# Altium schematic sheet SensorBoard.SchDoc
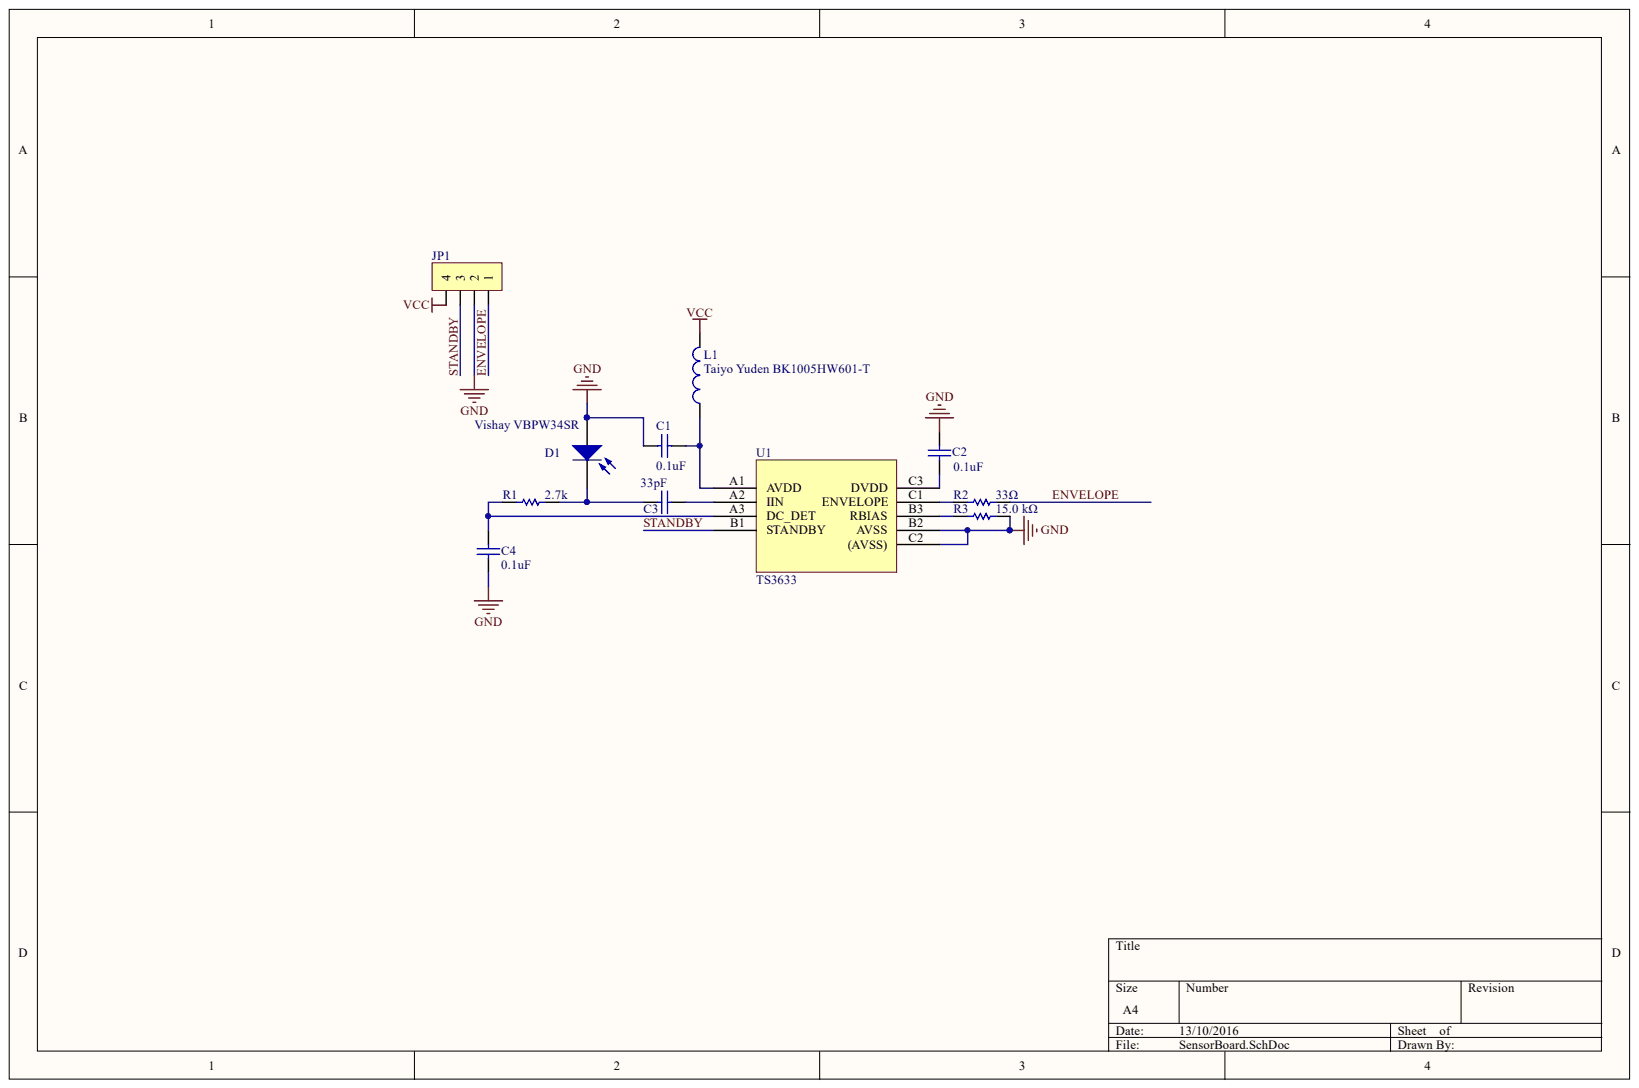
[diagram p0: SensorBoard.SchDoc]

## Parts
C1  Capacitance: 0.1uF  [CAPC1005X55X25NL05T10]  (hidden comment: Murata GRM155R71C104KA88J)
C2  Capacitance: 0.1uF  [CAPC1005X55X25NL05T10]  (hidden comment: Murata GRM155R71C104KA88J)
C3  Capacitance: 33pF  [CAPC1005X55X25NL05T10]  (hidden comment: Murata GRM1555C1H330JA01D)
C4  Capacitance: 0.1uF  [CAPC1005X55X25NL05T10]  (hidden comment: Murata GRM155R71C104KA88J)
D1  Vishay VBPW34SR  [PCB-1024939-1]
JP1  FFC PCB lands 4 pin  [PCB-2m0gw1boufmymuy9r0qo-1]  (value not drawn)
L1  Taiyo Yuden BK1005HW601-T  [CAPC1005X56X25NL10T15]
R1  Resistance: 2.7k  [CAPC1005X55X25NL05T10]  (hidden comment: Yageo RC0402FR-072K7L)
R2  Resistance: 33Ω  [CAPC1005X55X25NL05T10]  (hidden comment: Yageo RC0402FR-0733RL)
R3  Resistance: 15.0 kΩ  [CAPC1005X55X25NL05T10]  (hidden comment: RC0402FR-0715KL)
U1  TS3633  [BGA9C50P3X3_166X166X60]

## Nets
GND: C1.2 C2.1 C4.2 D1.1 JP1.2 R3.2 U1.B2(AVSS) U1.C2((AVSS))
VCC: JP1.4 L1.2
ENVELOPE: JP1.1 R2.2
STANDBY: JP1.3 U1.B1(STANDBY)
NetC1_1: C1.1 L1.1 U1.A1(AVDD)
NetC2_2: C2.2 U1.C3(DVDD)
NetC3_1: C3.1 D1.2 R1.2
NetC3_2: C3.2 U1.A2(IIN)
NetC4_1: C4.1 R1.1 U1.A3(DC_DET)
NetR2_1: R2.1 U1.C1(ENVELOPE)
NetR3_1: R3.1 U1.B3(RBIAS)

## Library data of the parts
C1 C2 C4 = CMP-131530-4: Multilayer Ceramic Capacitors MLCC - SMD/SMT 0.1uF 16 volts 10%; Dielectric Characteristic: X7R; Lead-Free Status: Lead Free; Voltage Rating (DC): 16.0 V; Capacitance Tolerance: ±10%; Component Kind: Standard; Library Name: CommunityVault; Lifecycle Status: Active; Manufacturer Part Number: GRM155R71C104KA88J; OctopartID: 7e0fb789e72fa1c8; Octopart Url: https://octopart.com/grm155r71c104ka88j-murata-9223238; RoHS: Compliant; Size-Length: 0.001 m; Size-Thickness: 0.0005 m; Size-Width: 0.0005 m
C3 = CMP-2265478-2: Cap Ceramic 33pF 50V C0G 5% SMD 0402 125C Paper T/R; Dielectric Characteristic: C0G/NP0; Lead-Free Status: Lead Free; Voltage Rating (DC): 50.0 V; Capacitance Tolerance: ±5%; Component Kind: Standard; Library Name: CommunityVault; Lifecycle Status: Active; OctopartID: dab413591ab90573; Octopart Url: https://octopart.com/grm1555c1h330ja01d-murata-15197547; Packaging: Cut Tape (CT); REACH SVHC Compliance: No SVHC; RoHS: Compliant; Size-Length: 0.001 m; Size-Thickness: 0.0005 m; Size-Width: 0.0005 m
D1 = CMP-1024939-7: VISHAY   VBPW34SR   PHOTOPIN DIODE RG; Breakdown Voltage: 60.0 V; Lead-Free Status: Lead Free; Wavelength: 9.4e-07 m; Component Kind: Standard; Library Name: CommunityVault; Number of Pins: 2; OctopartID: 7148b95d730bae8f; Octopart Url: https://octopart.com/vbpw34sr-vishay-18818853; RoHS: Compliant
JP1 = CMP-aomp0ps5nfz5sokcn3fi-1: Direct mount 4 contact; Component Kind: Standard; CustomComponentID: aomp0ps5nfz5sokcn3fi; Library Name: CommunityVault
L1 = CMP-fac633c940f52501-1: Ind Chip Bead Shielded Multi-Layer 600Ohm 25% 100MHz Ferrite 300mA 0402 T/R; Lead-Free Status: Lead Free; Resistance: 600 m?; Shielding: No; Case/Package: 0402; Component Kind: Standard; Current Rating: 300 mA; Frequency: 100 MHz; Halogen Free Status: Halogen Free; Library Name: FavoritesLibrary; Lifecycle Status: Active; Manufacturer Part Number: BK1005HW601-T; Mounting Style: Surface Mount; Number of Pins: 2; OctopartID: fac633c940f52501; Octopart URL: http://octopart.com/bk1005hw601-t-taiyo+yuden-368469; Packaging: Cut Tape (CT); RoHS: Compliant; Size-Height: 500 µm; Size-Length: 1.00 mm; Size-Width: 500 µm
R1 = CMP-1875429-5: Surface Mount Thick Film Resistor, RC Series, 2.7 kohm, 63 mW, - 1%, 50 V, 0402 [1005 Metric]; Component Kind: Standard; Library Name: CommunityVault
R2 = CMP-1853176-7: Res Thick Film 1.0 x 0.5 mm 33 Ohm 1% 0.063W(1/16W) 100ppm/ C Molded SMD Paper T/R; Component Kind: Standard; Library Name: CommunityVault
R3 = CMP-0ac7c3de0c1cd500-2: RC Series 0402 0.063 W 15 kOhms ±1% ±100 ppm/°C SMT Thick Film Chip Resistor; Component Kind: Standard; Library Name: CommunityVault
U1 = CMP-cl92bupb5nte3owvtngo-2: Component Kind: Standard; Description: TS3633; Library Name: CommunityVault
